# Altium schematic sheet DE-220817.SchDoc(DE-220817)
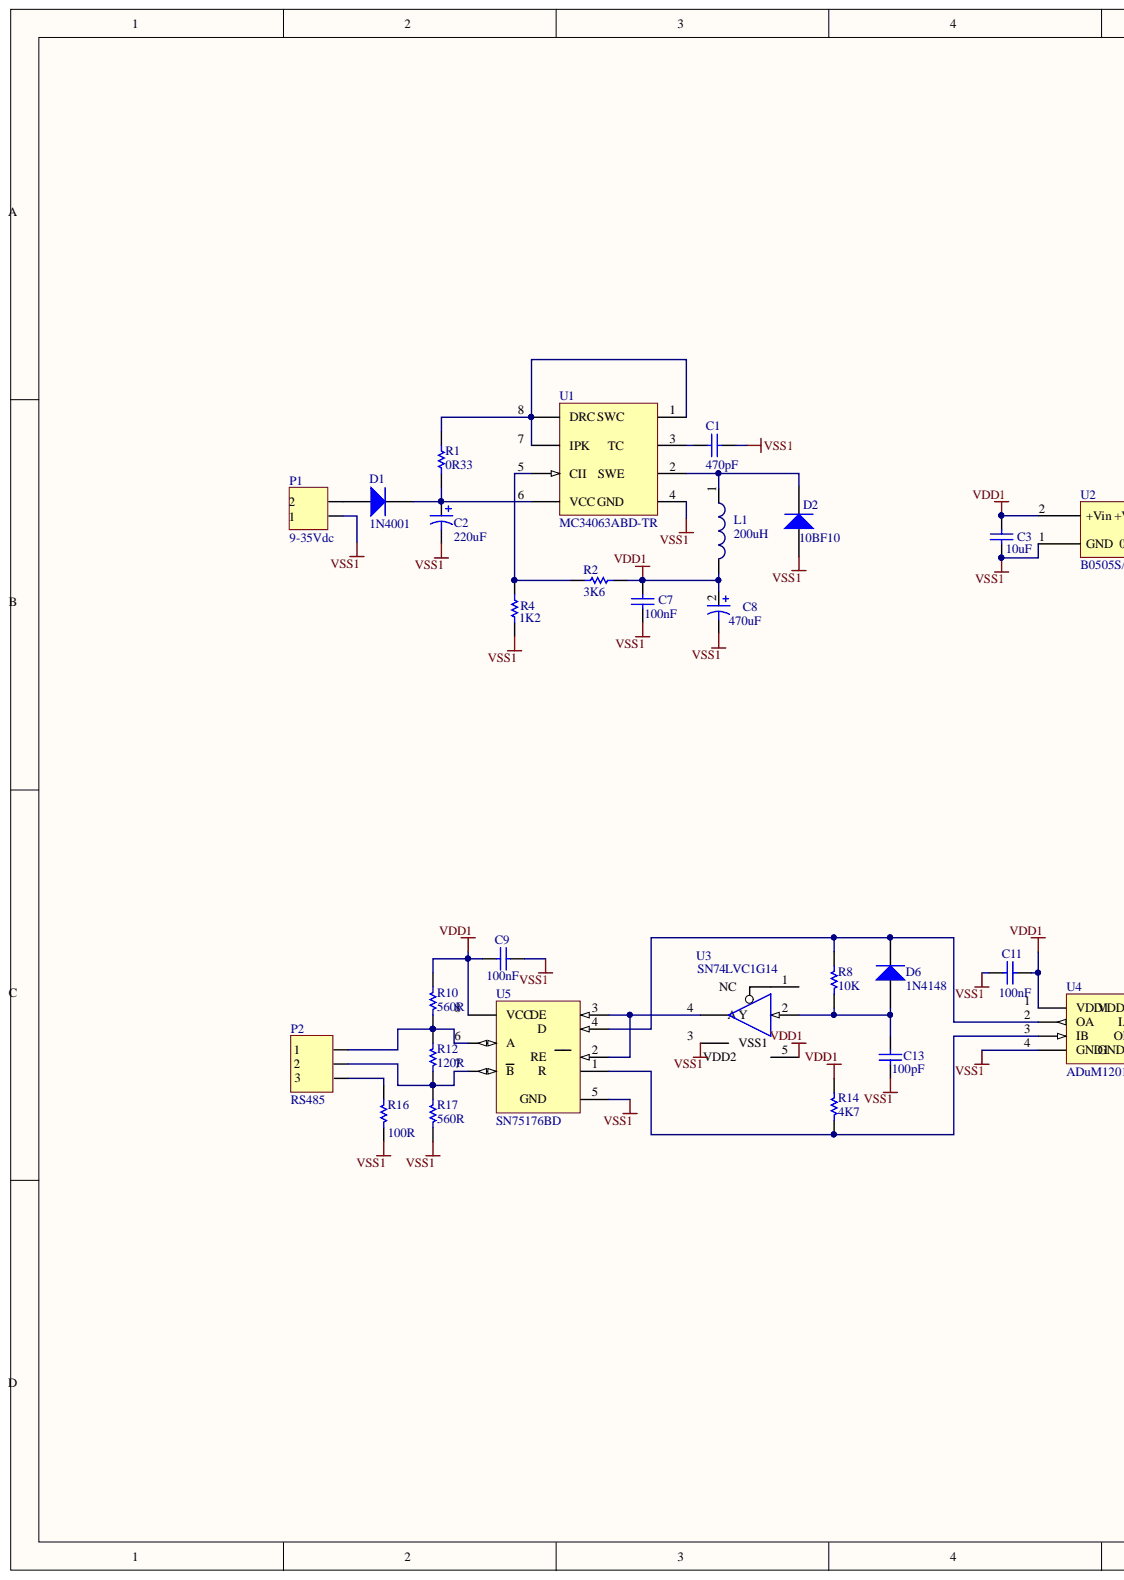
[diagram p0: DE-220817.SchDoc, left]
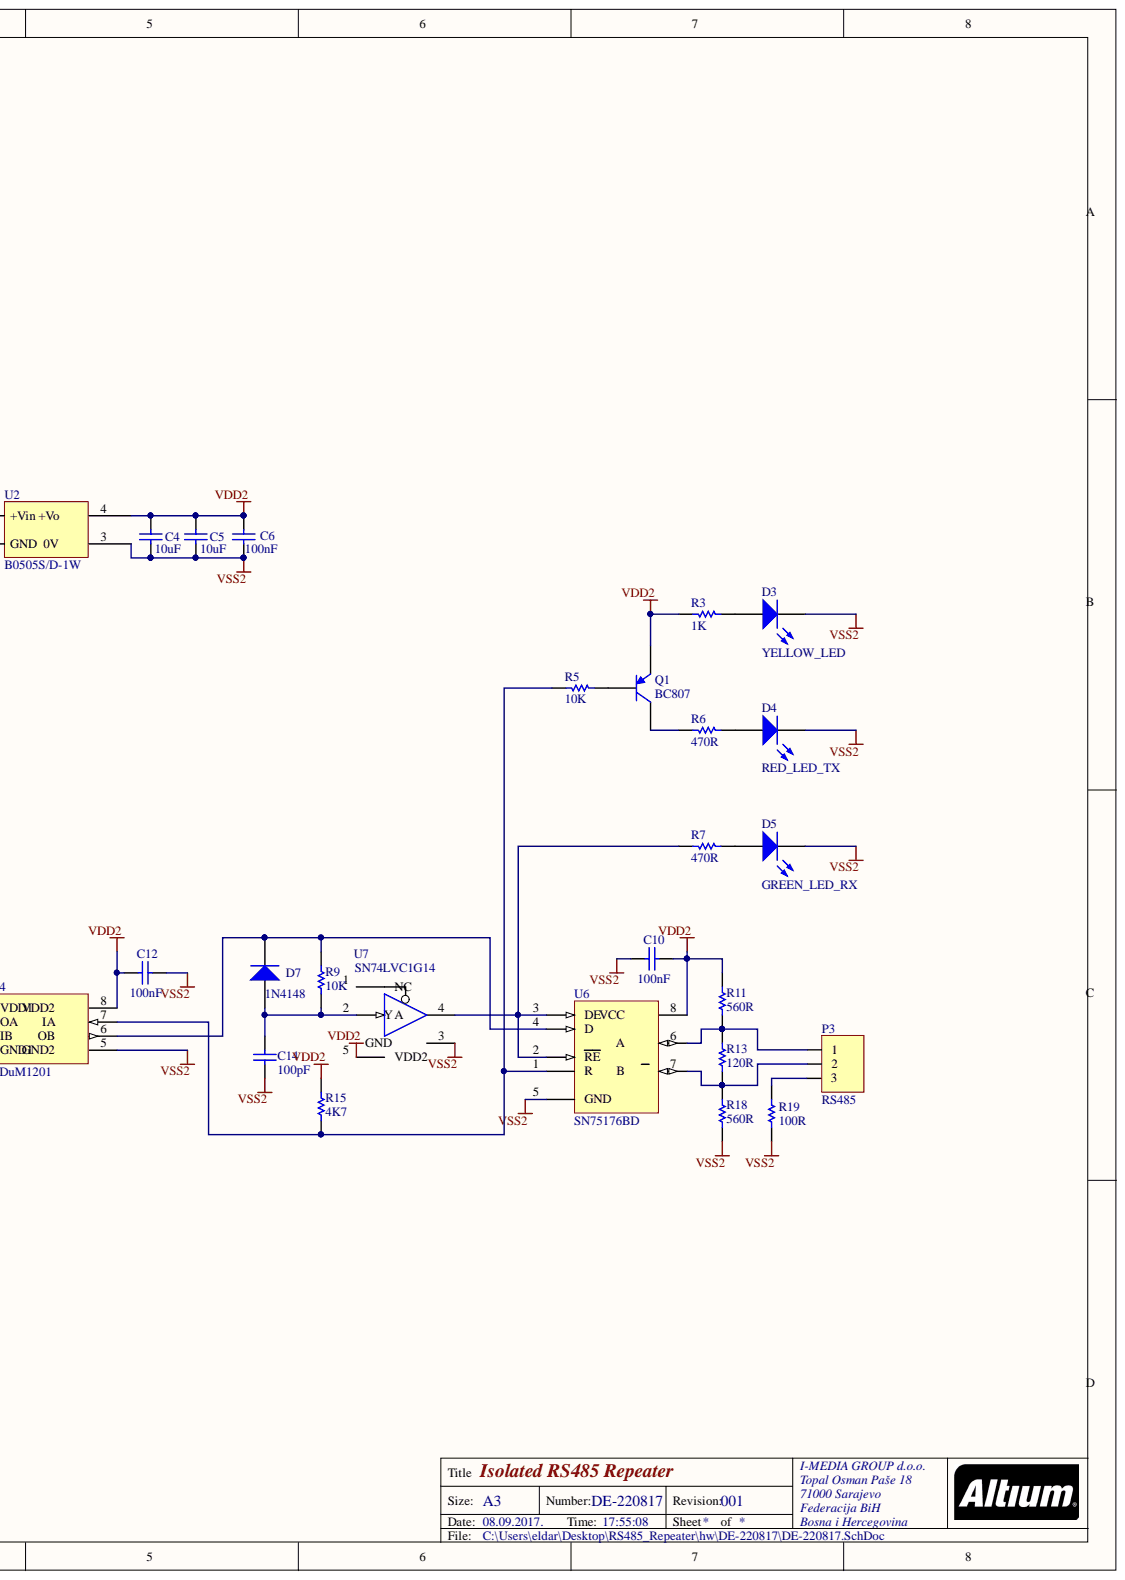
[diagram p1: DE-220817.SchDoc, right]

## Parts
C1  470pF  [0603]
C2  220uF  [CAPPR5-10X12.5]
C3  10uF  [0805]
C4  10uF  [0805]
C5  10uF  [0805]
C6  100nF  [0603]
C7  100nF  [0603]
C8  470uF  [CAPPR3.5-8X11.5]
C9  100nF  [0603]
C10  100nF  [0603]
C11  100nF  [0603]
C12  100nF  [0603]
C13  100pF  [0603]
C14  100pF  [0603]
D1  1N4001  [SMB]
D2  10BF10  [SMB]
D3  YELLOW_LED  [2.0X1.25X1.2]
D4  RED_LED_TX  [2.0X1.25X1.2]
D5  GREEN_LED_RX  [2.0X1.25X1.2]
D6  1N4148  [SOD323]
D7  1N4148  [SOD323]
L1  value 200uH  [CD54]  (hidden comment: 74437368220)
P1  9-35Vdc  [TERMINAL BLOK 2]
P2  RS485  [TERMINAL BLOK 3]
P3  RS485  [TERMINAL BLOK 3]
Q1  BC807  [SOT23_N]
R1  0R33  [ERA-0805]
R2  3K6  [ERA-0603]
R3  1K  [ERA-0603]
R4  1K2  [ERA-0603]
R5  10K  [ERA-0603]
R6  470R  [ERA-0603]
R7  470R  [ERA-0603]
R8  10K  [ERA-0603]
R9  10K  [ERA-0603]
R10  560R  [ERA-0805]
R11  560R  [ERA-0805]
R12  120R  [ERA-0805]
R13  120R  [ERA-0805]
R14  4K7  [ERA-0603]
R15  4K7  [ERA-0603]
R16  value 100R  [8RB-1206]  (hidden comment: ERJ8BQ)
R17  560R  [ERA-0805]
R18  560R  [ERA-0805]
R19  value 100R  [8RB-1206]  (hidden comment: ERJ8BQ)
U1  MC34063ABD-TR  [SO8_N]
U2  B0505S/D-1W  [B0505S-1W]
U3  SN74LVC1G14  [419A-01_N]
U4  ADuM1201  [SOP8]
U5  SN75176BD  [D008_N]
U6  SN75176BD  [D008_N]
U7  SN74LVC1G14  [419A-01_N]

## Nets
VDD1: C3.2 C7.2 C8.1 C9.1 C11.2 L1.2 R2.2 R10.2 R14.2 U2.2 U3.5 U4.1(VDD1) U5.8(VCC)
VDD2: C4.2 C5.2 C6.2 C10.1 C12.2 Q1.2 R3.2 R11.2 R15.2 U2.4 U4.8 U6.8 U7.5(VDD2)
VSS1: C1.1 C2.2 C3.1 C7.1 C8.2 C9.2 C11.1 C13.1 D2.1 P1.1 R4.1 R16.1 R17.1 U1.4 U2.1(GND) U3.3(VSS1) U4.4(GND1) U5.5
VSS2: C4.1 C5.1 C6.1 C10.2 C12.1 C14.1 D3.2 D4.2 D5.2 R18.1 R19.1 U2.3 U4.5 U6.5(GND) U7.3
NetC1_2: C1.2 U1.3
NetC2_1: C2.1 D1.2 R1.1 U1.6
NetC13_2: C13.2 D6.2 R8.1 U3.2(Y)
NetC14_2: C14.2 D7.2 R9.1 U7.2(Y)
NetD1_1: D1.1 P1.2
NetD2_2: D2.2 L1.1 U1.2
NetD3_1: D3.1 R3.1
NetD4_1: D4.1 R6.1
NetD5_1: D5.1 R7.1
NetD6_1: D6.1 R8.2 U4.2(OA) U5.4
NetD7_1: D7.1 R9.2 U4.6 U6.4(D)
NetP2_1: P2.1 R10.1 R12.2 U5.6(A)
NetP2_2: P2.2 R12.1 R17.2 U5.7(B)
NetP2_3: P2.3 R16.2
NetP3_1: P3.1 R11.1 R13.2 U6.6
NetP3_2: P3.2 R13.1 R18.2 U6.7
NetP3_3: P3.3 R19.2
NetQ1_1: Q1.1 R5.1
NetQ1_3: Q1.3 R6.2
NetR1_2: R1.2 U1.1 U1.7(IPK) U1.8
NetR2_1: R2.1 R4.2 U1.5(CII)
NetR5_2: R5.2 R15.1 U4.7 U6.1(R)
NetR7_2: R7.2 U6.2(RE) U6.3(DE) U7.4(A)
NetR14_1: R14.1 U4.3(IB) U5.1
NetU3_4: U3.4(A) U5.2 U5.3

Not connected: U3 1; U7 1(NC)

## Library data of the parts
C1 C6 C7 C9-C14 = C0603: Ceramic Chip Capacitor - Standard; Note: Footprint corresponds to dimensions for bulk cassette packaging. This case size has tightened tolerances.; Code_IPC: 1608 [0603]; Code_JEITA: 0603; Component Kind: Standard; ComponentLink1Description: Manufacturer Link; ComponentLink1URL: http://www.kemet.com/; ComponentLink2Description: Datasheet; ComponentLink2URL: http://www.kemet.com/kemet/web/homepage/kechome.nsf/vapubfilesname/F3102TA.pdf/$file/F3102TA.pdf; DatasheetDocument: Mar-2001; LatestRevisionDate: 19-Mar-2004; LatestRevisionNote: Silkscreen size reduced.; Library Name: DE-220817.SchLib; PackageDocument: Mar-2001; PackageReference: 0603; Published: 17-Jul-2002; Signal Integrity: C0603; Simulation: CAP; Publisher: Altium Limited
  C1: Value: 470pF
  C6 C7 C9-C12: Value: 100nF
  C13 C14: Value: 100pF
C2 = EKA00DC322H00: Aluminum Electrolytic Capacitor, Radial Style with Long Leads: 220uF, 50V; DrillSize: Nominal, Rounded To The Nearest 0.1mm; Note: Refer to Manufacturer's Data Sheets for other lead formations; Component Kind: Standard; ComponentLink1Description: Manufacturer Link; ComponentLink1URL: http://www.vishay.com/; ComponentLink2Description: Datasheet; ComponentLink2URL: http://www.vishay.com/docs/25014/eka.pdf; DatasheetDocument: 20-Feb-2001; PackageReference: CAPPR5-10X12.5; Published: 19-Mar-2004; Signal Integrity: EKA00DC322H00; Simulation: CAP; Value: 220uF; Library Name: Vishay Roederstein Electrolytic Radial-Lead Capacitor.IntLib; Publisher: Altium Limited
C3-C5 = C0805: Ceramic Chip Capacitor - Standard; Note: Footprint corresponds to dimensions for bulk cassette packaging. This case size has tightened tolerances.; Code_IPC: 2012[0805]; Code_JEITA: 0805; Component Kind: Standard; ComponentLink1Description: Manufacturer Link; ComponentLink1URL: http://www.kemet.com/; ComponentLink2Description: Datasheet; ComponentLink2URL: http://www.kemet.com/kemet/web/homepage/kechome.nsf/vapubfilesname/F3102TA.pdf/$file/F3102TA.pdf; DatasheetDocument: Mar-2001; LatestRevisionDate: 19-Mar-2004; LatestRevisionNote: Silkscreen size reduced.; Library Name: DE-220817.SchLib; PackageDocument: Mar-2001; PackageReference: 0805; Published: 17-Jul-2002; Signal Integrity: C0805; Simulation: CAP; Value: 10uF; Publisher: Altium Limited
C8 = EKA00DC347D00: Aluminum Electrolytic Capacitor, Radial Style with Long Leads: 470uF, 16V; DrillSize: Nominal, Rounded To The Nearest 0.1mm; Note: Refer to Manufacturer's Data Sheets for other lead formations; Component Kind: Standard; ComponentLink1Description: Manufacturer Link; ComponentLink1URL: http://www.vishay.com/; ComponentLink2Description: Datasheet; ComponentLink2URL: http://www.vishay.com/docs/25014/eka.pdf; DatasheetDocument: 20-Feb-2001; PackageReference: CAPPR3.5-8X11.5; Published: 19-Mar-2004; Signal Integrity: EKA00DC347D00; Simulation: CAP; Value: 470uF; Library Name: Vishay Roederstein Electrolytic Radial-Lead Capacitor.IntLib; Publisher: Altium Limited
D1 D2 = 10BF10: Surface Mountable Ultrafast Recovery Diode; Component Kind: Standard; ComponentLink1Description: Manufacturer Link; ComponentLink1URL: http://www.irf.com/; ComponentLink2Description: Datasheet; ComponentLink2URL: http://www.irf.com/product-info/datasheets/data/10bf.pdf; DatasheetVersion: Jun-1999; LatestRevisionDate: 17-Jul-2002; LatestRevisionNote: Re-released for DXP Platform.; Library Name: IR Rectifier - Ultrafast Recovery.IntLib; PackageDescription: DO-214-AA/SMB; 2 C-Bend Leads; Body 5.3 x 3.6 mm, inc. leads (LxW); PackageReference: SMB; Published: 8-Jun-2000; Signal Integrity: 10BF10; Publisher: Altium Limited
D3-D5 = HSMC-C540-F0001: Top Mount AS AlInGaP Red Oval Lens High Brightness; LuminousIntensity: 96mcd (Minimum).; PCB_Layout: Complies with manufacturer's recommendation.; Component Kind: Standard; ComponentLink1Description: Manufacturer Link; ComponentLink1URL: http://www.agilent.com/; ComponentLink2Description: Datasheet; ComponentLink2URL: http://cp.literature.agilent.com/litweb/pdf/5988-5794EN.pdf; DatasheetDocument: 7-Mar-2002; LED_Colour: Red; Library Name: DE-220817.SchLib; PackageDocument: 30-Apr-2002; PackageReference: 2.0X1.25X1.2; Published: 11-Dec-2002; Signal Integrity: LED; Simulation: Agl_HSMAC540; Publisher: Altium Limited
D6 D7 = BAS321: General-purpose Diode; Component Kind: Standard; ComponentLink1Description: Manufacturer Link; ComponentLink1URL: http://www.semiconductors.philips.com/; ComponentLink2Description: Datasheet; ComponentLink2URL: http://www.semiconductors.philips.com/acrobat/datasheets/BAS321_2.pdf; DatasheetVersion: 9-Feb-1999; LatestRevisionDate: 19-Mar-2004; LatestRevisionNote: Silkscreen size reduced.; Library Name: Philips Discrete Diode - Switching.IntLib; PackageDescription: SOD-323/SC-76; 2 Leads; Body 1.7 x 1.3 mm, (LxW); PackageReference: SOD323; PackageVersion: May-1999; Published: 17-Sep-2003; Signal Integrity: BAS321; Simulation: BAS321; Publisher: Altium Limited
L1 = 74437368220: SMD Power Inductor WE-LHMI, L=22.0 µH; Inductance: 22.0 µH; Component Kind: Standard; ComponentLink1Description: Manufacturer URL; ComponentLink1URL: http://www.we-online.com; ComponentLink2Description: Datasheet; ComponentLink2URL: http://katalog.we-online.de/kataloge/eisos/media/pdf/74437368220.pdf; Library Name: WE-LHMI SMD Power Inductor.IntLib; PackageReference: WE-LHMI 1040; PartNumber: 74437368220
P1 = TERMINAL BLOK 2: Terminal blok konektor 3,5 mm; Component Kind: Standard; Library Name: DE-220817.SchLib
P2 P3 = TERMINAL BLOK 3: 3 pinski terminal blok konektor; Component Kind: Standard; Library Name: DE-220817.SchLib
Q1 = BC807: PNP General-purpose Transistor; Component Kind: Standard; ComponentLink1Description: Manufacturer Link; ComponentLink1URL: http://www.semiconductors.philips.com/; ComponentLink2Description: Datasheet; ComponentLink2URL: http://www.semiconductors.philips.com/acrobat/datasheets/BC807_BC807W_BC327_5.pdf; DatasheetVersion: 8-Apr-1999; LatestRevisionDate: 12-Dec-2006; LatestRevisionNote: IPC-7351 Footprint Added.; Library Name: DE-220817.SchLib; PackageDescription: 3-Pin SOT-23 Package 0.95 mm Pitch, 2.3 mm Lead Span; PackageReference: SOT23; PackageVersion: May-1999; Published: 17-Sep-2003; Signal Integrity: BC807; Simulation: QBC807; Publisher: Altium Limited
R1 = ERAS: Metal Film Thermosensitive Chip Resistor, 0.56 Ohm to 10k Ohm Range, 5% Tolerance, 0805 Size, 0.1 W; Note: If flow soldering, adjust pad width of PCB Footprint to 0.7 - 0.8 times the width of the resistor.; Code_IPC: 2012 [0805]; Component Kind: Standard; ComponentLink1Description: Manufacturer Link; ComponentLink1URL: http://www.panasonic.com/industrial/components/resistive_products.htm; ComponentLink2Description: Datasheet; ComponentLink2URL: http://www.panasonic.com/industrial/components/pdf/AOF0000CE1.pdf; LatestRevisionDate: 19-Mar-2004; LatestRevisionNote: Silkscreen size reduced.; Library Name: DE-220817.SchLib; PackageDocument: Mar-2003; PackageReference: ERA-0805; Published: 17-Dec-2003; Signal Integrity: Res; Simulation: RESISTOR; Publisher: Altium Limited
R2-R9 R14 R15 = ERAV: Metal Film Thermosensitive Chip Resistor, 0.56 Ohm to 10k Ohm Range, 5% Tolerance, 0603 Size, 0.063 W; Note: If flow soldering, adjust pad width of PCB Footprint to 0.7 - 0.8 times the width of the resistor.; Code_IPC: 1608 [0603]; Component Kind: Standard; ComponentLink1Description: Manufacturer Link; ComponentLink1URL: http://www.panasonic.com/industrial/components/resistive_products.htm; ComponentLink2Description: Datasheet; ComponentLink2URL: http://www.panasonic.com/industrial/components/pdf/AOF0000CE1.pdf; LatestRevisionDate: 19-Mar-2004; LatestRevisionNote: Silkscreen size reduced.; Library Name: DE-220817.SchLib; PackageDocument: Mar-2003; PackageReference: ERA-0603; Published: 17-Dec-2003; Signal Integrity: Res; Simulation: RESISTOR; Publisher: Altium Limited
R10-R13 R17 R18 = ERA6Y: Rectangular Thick Film Chip Resistor, 10 Ohm to 1M Ohm Range, 0.1% and 0.5% Tolerance, 0805 Size, 0.1 W; Note: If flow soldering, adjust pad width of PCB Footprint to 0.7 - 0.8 times the width of the resistor.; Code_IPC: 2012 [0805]; Component Kind: Standard; ComponentLink1Description: Manufacturer Link; ComponentLink1URL: http://www.panasonic.com/industrial/components/resistive_products.htm; ComponentLink2Description: Datasheet; ComponentLink2URL: http://www.panasonic.com/industrial/components/pdf/AOA0000CE8.pdf; LatestRevisionDate: 19-Mar-2004; LatestRevisionNote: Silkscreen size reduced.; Library Name: DE-220817.SchLib; PackageDocument: Mar-2003; PackageReference: ERA-0805; Published: 17-Dec-2003; Signal Integrity: Res; Simulation: RESISTOR; Publisher: Altium Limited
R16 R19 = ERJ8BQ: Low Ohmic Value Thick Film Chip Resistor, 0.22 Ohm to 9.1 Ohm Range, 1%, 2% and 5% Tolerance, 1206 Size, 0.33 W; Code_IPC: 3216 [1206]; Component Kind: Standard; ComponentLink1Description: Manufacturer Link; ComponentLink1URL: http://www.panasonic.com/industrial/components/resistive_products.htm; ComponentLink2Description: Datasheet; ComponentLink2URL: http://www.panasonic.com/industrial/components/pdf/AOA0000CE3.pdf; LatestRevisionDate: 19-Mar-2004; LatestRevisionNote: Silkscreen size reduced.; Library Name: Panasonic Resistor.IntLib; PackageDocument: Mar-2003; PackageReference: 8RB-1206; Published: 17-Dec-2003; Signal Integrity: Res; Simulation: RESISTOR; Publisher: Altium Limited
U1 = MC34063ABD-TR: DC/DC converter control circuit, 8-Pin SO, Tape and Reel; Code_IPC: SOIC127P600-8; Code_JEDEC: MS-012-AA; Component Kind: Standard; ComponentLink1Description: Manufacturer URL; ComponentLink1URL: http://www.st.com; ComponentLink2Description: Datasheet; ComponentLink2URL: http://www.st.com/internet/com/TECHNICAL_RESOURCES/TECHNICAL_LITERATURE/DATASHEET/CD00001232.pdf; ComponentLink3Description: Package Specification; ComponentLink3URL: http://www.st.com/internet/com/TECHNICAL_RESOURCES/TECHNICAL_LITERATURE/PACKAGE_INFORMATION/CD00004680.pdf; DatasheetVersion: Nov-2007; Library Name: STMicroelectronics Power Management Switching Regulator.IntLib; PackageDescription: 8-Pin Small Outline Integrated Circuit 1.27 mm Pitch; PackageReference: SO8; PackageVersion: Dec-2002
U2 = B0505S/D: 1W isolated dc dc converter; Component Kind: Standard; Library Name: DE-220817.SchLib
U3 U7 = MC74VHC1G125DFT1: Non-Inverting 3-State Buffer; Component Kind: Standard; ComponentLink1Description: Manufacturer Link; ComponentLink1URL: http://www.onsemi.com/; ComponentLink2Description: Datasheet; ComponentLink2URL: http://www.onsemi.com/pub/Collateral/MC74VHC1G125-D.PDF; DatasheetVersion: Feb-2000; LatestRevisionDate: 12-Dec-2006; LatestRevisionNote: IPC-7351 Footprint Added.; Library Name: ON Semi Logic Buffer Line Driver.IntLib; PackageDescription: 5-Pin SOT-353 Package 0.65 mm Pitch, 2.1 mm Lead Span; PackageReference: 419A-01; PackageVersion: Oct-1999; Published: 15-Apr-2002; Publisher: Altium Limited
U4 = ADuM1201: Digital Isolator; Component Kind: Standard; Library Name: DE-220817.SchLib
U5 U6 = SN75176BD: Differential Bus Transceiver; Note: Receiver Hysteresis of 50mV Typical; Code_IPC: SOIC127P600-8; Component Kind: Standard; ComponentLink1Description: Manufacturer Link; ComponentLink1URL: http://www.ti.com/; ComponentLink2Description: Datasheet; ComponentLink2URL: http://www-s.ti.com/sc/ds/sn75176b.pdf; DatasheetVersion: Sep-1989; LatestRevisionDate: 13-Apr-2006; LatestRevisionNote: IPC-7351 Footprint Added.; Library Name: DE-220817.SchLib; PackageDescription: 8-Pin Small Outline Integrated Circuit 1.27 mm Pitch; PackageReference: D008; PackageVersion: Jan-1998; PCB3D: D008; Published: 15-May-2002; Publisher: Altium Limited
